# Altium schematic sheet 10 - POWER, RESET.SchDoc
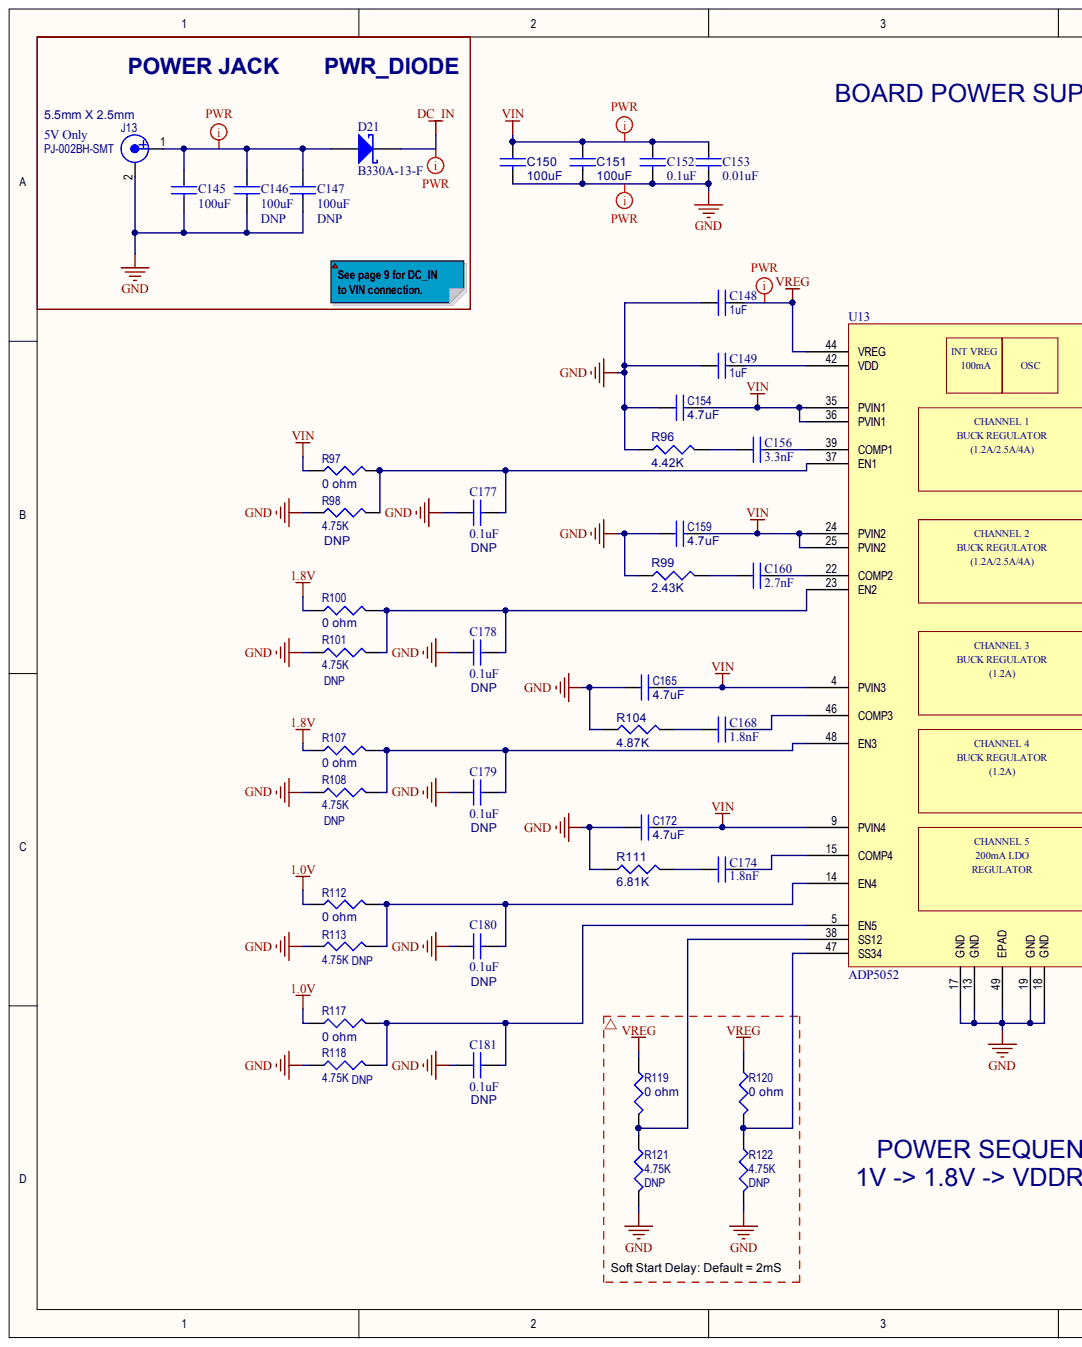
[diagram p0: 10 - POWER, RESET.SchDoc, left]
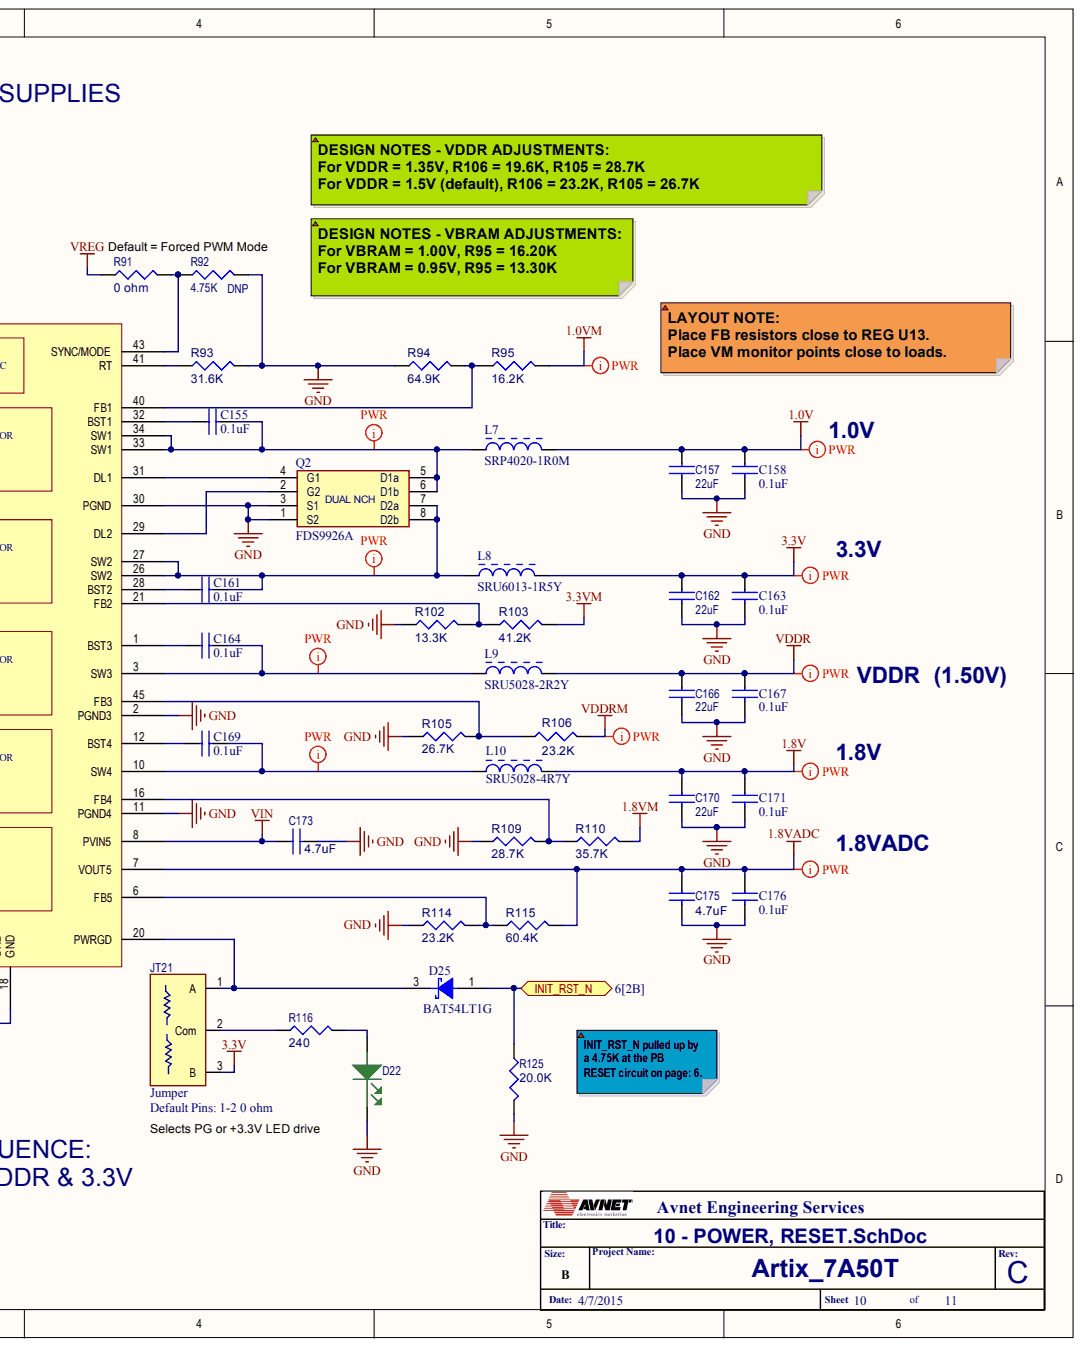
[diagram p1: 10 - POWER, RESET.SchDoc, right]

## Parts
C145  value 100uF  [C1210]  (hidden comment: PC074)
C146  value 100uF  [C1210]  (hidden comment: PC074)
C147  value 100uF  [C1210]  (hidden comment: PC074)
C148  value 1uF  [C0402]  (hidden comment: CAP_GEN_1uF_0402)
C149  value 1uF  [C0402]  (hidden comment: CAP_GEN_1uF_0402)
C150  100uF  [C1210]
C151  100uF  [C1210]
C152  value 0.1uF  [C0201]  (hidden comment: PC522)
C153  value 0.01uF  [C0201]  (hidden comment: PC003)
C154  value 4.7uF  [C0402]  (hidden comment: 4.8uF)
C155  value 0.1uF  [C0201]  (hidden comment: PC522)
C156  value 3.3nF  [C0201]  (hidden comment: ASYTXT)
C157  value 22uF  [C0805]  (hidden comment: GRM219R61A226MEA0D)
C158  value 0.1uF  [C0201]  (hidden comment: PC522)
C159  value 4.7uF  [C0402]  (hidden comment: 4.8uF)
C160  value 2.7nF  [C0201]  (hidden comment: ASYTXT)
C161  value 0.1uF  [C0201]  (hidden comment: PC522)
C162  value 22uF  [C0805]  (hidden comment: GRM219R61A226MEA0D)
C163  value 0.1uF  [C0201]  (hidden comment: PC522)
C164  value 0.1uF  [C0201]  (hidden comment: PC522)
C165  value 4.7uF  [C0402]  (hidden comment: 4.8uF)
C166  value 22uF  [C0805]  (hidden comment: GRM219R61A226MEA0D)
C167  value 0.1uF  [C0201]  (hidden comment: PC522)
C168  value 1.8nF  [C0201]  (hidden comment: ASYTXT)
C169  value 0.1uF  [C0201]  (hidden comment: PC522)
C170  value 22uF  [C0805]  (hidden comment: GRM219R61A226MEA0D)
C171  value 0.1uF  [C0201]  (hidden comment: PC522)
C172  value 4.7uF  [C0402]  (hidden comment: 4.8uF)
C173  value 4.7uF  [C0402]  (hidden comment: 4.8uF)
C174  value 1.8nF  [C0201]  (hidden comment: ASYTXT)
C175  value 4.7uF  [C0402]  (hidden comment: 4.8uF)
C176  value 0.1uF  [C0201]  (hidden comment: PC522)
C177  value 0.1uF  [C0201]  (hidden comment: PC522)
C178  value 0.1uF  [C0201]  (hidden comment: PC522)
C179  value 0.1uF  [C0201]  (hidden comment: PC522)
C180  value 0.1uF  [C0201]  (hidden comment: PC522)
C181  value 0.1uF  [C0201]  (hidden comment: PC522)
D21  Device: B330A-13-F  [SMA]  (hidden comment: DD031)
D22  DL018  [LED_LITE-LTST-C191TBKT-G]  (value not drawn)
D25  BAT54LT1G  [SOT23]
J13  Device: PJ-002BH-SMT  [CON_CUI-PJ-002B-SMT]  (hidden comment: EC068)
JT21  value 0 ohm  Default Pins: 1-2 0 ohm  Device: Jumper  [R0402-DUAL_JMP]
L7  SRP4020-1R0M  [AVPI-1B]
L8  SRU6013-1R5Y  [AVPI-2C]
L9  SRU5028-2R2Y  [AVPI-2C]
L10  SRU5028-4R7Y  [AVPI-2C]
Q2  MFGPN: FDS9926A  [so8]  (hidden comment: FDS9926A DUAL MOSFET)
R91  0 ohm  [R0402]
R92  value 4.75K  [R0402]  (hidden comment: 0402)
R93  value 31.6K  [R0402]  (hidden comment: 0402 RES, 1%, Generic)
R94  value 64.9K  [R0402]  (hidden comment: 0402 RES, 1%, Generic)
R95  value 16.2K  [R0402]  (hidden comment: 0402 RES, 1%, Generic)
R96  value 4.42K  [R0402]  (hidden comment: 0402 RES, 1%, Generic)
R97  0 ohm  [R0402]
R98  value 4.75K  [R0402]  (hidden comment: 0402)
R99  value 2.43K  [R0402]  (hidden comment: 0402 RES, 1%, Generic)
R100  0 ohm  [R0402]
R101  value 4.75K  [R0402]  (hidden comment: 0402)
R102  value 13.3K  [R0402]  (hidden comment: 0402 RES, 1%, Generic)
R103  value 41.2K  [R0402]  (hidden comment: 0402 RES, 1%, Generic)
R104  value 4.87K  [R0402]  (hidden comment: 0402 RES, 1%, Generic)
R105  value 26.7K  [R0402]  (hidden comment: 0402 RES, 1%, Generic)
R106  value 23.2K  [R0402]  (hidden comment: 0402 RES, 1%, Generic)
R107  0 ohm  [R0402]
R108  value 4.75K  [R0402]  (hidden comment: 0402)
R109  value 28.7K  [R0402]  (hidden comment: 0402 RES, 1%, Generic)
R110  value 35.7K  [R0402]  (hidden comment: 0402 RES, 1%, Generic)
R111  value 6.81K  [R0402]  (hidden comment: 0402 RES, 1%, Generic)
R112  0 ohm  [R0402]
R113  value 4.75K  [R0402]  (hidden comment: 0402)
R114  value 23.2K  [R0402]  (hidden comment: 0402 RES, 1%, Generic)
R115  value 60.4K  [R0402]  (hidden comment: 0402 RES, 1%, Generic)
R116  240  [R0402]
R117  0 ohm  [R0402]
R118  value 4.75K  [R0402]  (hidden comment: 0402)
R119  0 ohm  [R0402]
R120  0 ohm  [R0402]
R121  value 4.75K  [R0402]  (hidden comment: 0402)
R122  value 4.75K  [R0402]  (hidden comment: 0402)
R125  20.0K  [R0402]
U13  ADP5052  [LFCSP48]

## Ports
INIT_RST_N

## Nets
1.0V: C157.1 C158.1 L7.2 R112.1 R117.1
1.0VM: R95.2
1.8V: C170.1 C171.1 L10.2 R100.1 R107.1
1.8VADC: C175.1 C176.1 R115.2 U13.7(VOUT5)
1.8VM: R110.2
3.3V: C162.1 C163.1 JT21.3 L8.2
3.3VM: R103.2
DC_IN: D21.C
GND: C145.2 C146.2 C147.2 C148.1 C149.1 C150.2 C151.2 C152.2 C153.2 C154.1 C157.2 C158.2 C159.1 C162.2 C163.2 C165.1 C166.2 C167.2 C170.2 C171.2 C172.1 C173.2 C175.2 C176.2 C177.1 C178.1 C179.1 C180.1 C181.1 D22.C J13.2 Q2.1(S2) Q2.3(S1) R92.2 R93.2 R94.1 R96.1 R98.1 R99.1 R101.1 R102.1 R104.1 R105.1 R108.1 R109.1 R111.1 R113.1 R114.1 R118.1 R121.2 R122.2 R125.2 U13.2(PGND3) U13.11(PGND4) U13.13(GND) U13.17(GND) U13.18(GND) U13.19(GND) U13.30(PGND) U13.49(EPAD)
VDDR: C166.1 C167.1 L9.2
VDDRM: R106.2
VIN: C150.1 C151.1 C152.1 C153.1 C154.2 C159.2 C165.2 C172.2 C173.1 R97.1 U13.4(PVIN3) U13.8(PVIN5) U13.9(PVIN4) U13.24(PVIN2) U13.25(PVIN2) U13.35(PVIN1) U13.36(PVIN1)
VREG: C148.2 R91.1 R119.1 R120.1 U13.44(VREG)
INIT_RST_N: D25.1 R125.1 ; port INIT_RST_N
NetC145_1: C145.1 C146.1 C147.1 D21.A J13.1
NetC149_2: C149.2 U13.42(VDD)
NetC155_1: C155.1 U13.32(BST1)
NetC155_2: C155.2 L7.1 Q2.5(D1a) Q2.6(D1b) U13.33(SW1) U13.34(SW1)
NetC156_1: C156.1 R96.2
NetC156_2: C156.2 U13.39(COMP1)
NetC160_1: C160.1 R99.2
NetC160_2: C160.2 U13.22(COMP2)
NetC161_1: C161.1 U13.28(BST2)
NetC161_2: C161.2 L8.1 Q2.7(D2a) Q2.8(D2b) U13.26(SW2) U13.27(SW2)
NetC164_1: C164.1 U13.1(BST3)
NetC164_2: C164.2 L9.1 U13.3(SW3)
NetC168_1: C168.1 R104.2
NetC168_2: C168.2 U13.46(COMP3)
NetC169_1: C169.1 U13.12(BST4)
NetC169_2: C169.2 L10.1 U13.10(SW4)
NetC174_1: C174.1 R111.2
NetC174_2: C174.2 U13.15(COMP4)
NetC177_2: C177.2 R97.2 R98.2 U13.37(EN1)
NetC178_2: C178.2 R100.2 R101.2 U13.23(EN2)
NetC179_2: C179.2 R107.2 R108.2 U13.48(EN3)
NetC180_2: C180.2 R112.2 R113.2 U13.14(EN4)
NetC181_2: C181.2 R117.2 R118.2 U13.5(EN5)
NetD22_A: D22.A R116.2
NetD25_3: D25.3 JT21.1 U13.20(PWRGD)
NetJT21_2: JT21.2 R116.1
NetQ2_2: Q2.2(G2) U13.29(DL2)
NetQ2_4: Q2.4(G1) U13.31(DL1)
NetR91_2: R91.2 R92.1 U13.43(SYNC/MODE)
NetR93_1: R93.1 U13.41(RT)
NetR94_2: R94.2 R95.1 U13.40(FB1)
NetR102_2: R102.2 R103.1 U13.21(FB2)
NetR105_2: R105.2 R106.1 U13.45(FB3)
NetR109_2: R109.2 R110.1 U13.16(FB4)
NetR114_2: R114.2 R115.1 U13.6(FB5)
NetR119_2: R119.2 R121.1 U13.38(SS12)
NetR120_2: R120.2 R122.1 U13.47(SS34)

## Library data of the parts
C145-C147 = AVPC-0000074: CAP SM 100uF 10V 10% X5R C1210; PartCode: PC; AVLNo: AVPC-0000530; Database Table Name: Passive-Cap$; MFG Code: TYC; MFGPN: EMK325ABJ107MM-T; Volt: 16V; AsyTxt: PC074; Component Kind: Standard; ComponentLink1Description: Datasheet; ComponentLink2Description: MFR Website; Device: CAP; Dielectric: X5R; ESR: CAP; PkgType: SM; RoHS: Yes; Status: Preliminary; Tol: 10%; ComponentLink1URL: C:\AVDBLIB\DATASHEETS\AVX_CX5R.pdf; Library Name: Avnet_DBlib.DbLib
  C146 C147: DNP: DNP
C148 C149 = CAP_GEN_1uF_0402: MFGPN: 04026D105KAT2A; PKG: 0402; Component Kind: Standard; Library Name: Artix_7A50.SCHLIB
C150 C151 = AVPC-0000209: CAP SM 100uF 6.3V 20% X5R C1206; PartCode: PC; AsyTxt: PC209; Database Table Name: Passive-Cap$; MFG Code: MUR; MFGPN: GRM32EF50J107ZE20K; Power: 0; Availability: 180K-AV; AVLNo: AVPC-0000209; Component Kind: Standard; ComponentLink1Description: Datasheet; Cost: .41; Device: CAP; Dielectric: X5R; PkgType: SM; Received: 0; RoHS: Yes; Size: 9.11; Status: Preliminary; Tol: 20%; Value: 100uF; Volt: 6.3V; ComponentLink1URL: C:\AVDBLIB\DATASHEETS\GRM31CR60J107ME39.pdf; Library Name: Avnet_DBlib.DbLib
C152 C155 C158 C161 C163 C164 C167 C169 C171 C176-C181 = AVPC-0000522: CAP SM 0.1uF 10V 10% X5R C0201; PartCode: PC; Database Table Name: Passive-Cap$; MFG Code: AVX; MFGPN: 02016D104KAT2A; AsyTxt: PC522; AVLNo: AVPC-0000522; Component Kind: Standard; ComponentLink1Description: Datasheet; Device: CAP; Dielectric: X5R; PkgType: SM; RoHS: Yes; Status: Preliminary; Tol: 10%; Volt: 10V; ComponentLink1URL: C:\AVDBLIB\DATASHEETS\AVX_CCOG.pdf; Library Name: Avnet_DBlib.DbLib
  C177-C181: DNP: DNP
C153 = AVPC-0000003: CAP SM 0.01uF 10V 10% X5R C0201; PartCode: PC; Database Table Name: Passive-Cap$; MFG Code: AVX; MFGPN: 0201ZD103KAT2A; AsyTxt: PC003; AVLNo: AVPC-0000003; Component Kind: Standard; ComponentLink1Description: Datasheet; ComponentLink2Description: MFR Website; ComponentLink2URL: www.avx.com; Device: CAP; Dielectric: X5R; ESR: CAP; PkgType: SM; RoHS: Yes; Status: Preliminary; Tol: 10%; Volt: 10V; ComponentLink1URL: C:\AVDBLIB\DATASHEETS\AVX_CX5R.pdf; Library Name: Avnet_DBlib.DbLib
C154 C159 C165 C172 C173 C175 = AVPC-0000499: CAP SM 4.7uF 6.3V 20% X5R C0402 85C; PartCode: PC; AsyTxt: PC499; Database Table Name: Passive-Cap$; MFG Code: AVX; MFGPN: GRM155R60J475ME87D; Power: 0; Availability: 140K-DK; AVLNo: AVPC-0000499; Component Kind: Standard; ComponentLink1Description: Datasheet; Cost: .46; Device: CAP; Dielectric: X5R; PkgType: SM; Received: 0; RoHS: Yes; Size: 1.9; Status: Preliminary; Tol: 20%; Volt: 6.3V; ComponentLink1URL: C:\AVDBLIB\DATASHEETS\murata_caps_c02e.pdf; Library Name: Avnet_DBlib.DbLib
C156 = AVPC-0000003: CAP SM 3300pF 16V 10% X7R C0201; Database Table Name: Passive-Cap$; MFG: MUR; MFGPN: GRM033R71C332KA88D; PKG: 0201; TEMP: -55+125; Component Kind: Standard; Dielectric: X7R; RoHS: Yes; Tol: 10%; Volt: 16V; Library Name: Avnet_DBlib.DbLib
C157 C162 C166 C170 = (no symbol name): CAP SM 22uF 10V 20% X5R C0805; PartCode: PC; AsyTxt: PC112; AVLNo: AVPC-0000531; ESR: CAP-P; MFG Code: TAI; MFGPN: LMK212BJ226MG-T; Tol: 10%; Component Kind: Standard; ComponentLink1Description: Datasheet; Device: CAP; Dielectric: X5R; Library Name: Zynq_Z010_ZedStick.SchLib; PkgType: SM; RoHS: Yes; Status: Preliminary; Volt: 10V
C160 = AVPC-0000003: CAP SM 2700pF 16V 10% X7R C0201; Database Table Name: Passive-Cap$; Dielectric: X5R; MFG: MUR; MFGPN: GRM033R61A272KA01D; PKG: 0201; TEMP: -55+85; Component Kind: Standard; Tol: 10%; Volt: 16V; Library Name: Avnet_DBlib.DbLib
C168 C174 = AVPC-0000003: CAP SM 1800pF 16V 10% X7R C0201; Database Table Name: Passive-Cap$; MFG: MUR; MFGPN: GRM033R71C182KA88D; PKG: 0201; TEMP: -55+125; Component Kind: Standard; Dielectric: X7R; Tol: 10%; Volt: 16V; Library Name: Avnet_DBlib.DbLib
D21 = AVDD-0000031: Diode SM Barrier Rectifier Schottky 3A 30V    DIO-S SMA; PartCode: DD; Database Table Name: Discrete-Diode$; MFG Code: DII; AsyTxt: DD031; AVLNo: AVDD-0000031; Component Kind: Standard; Device Sub Type: Barrier Rectifier; Device Type: Diode; Imax: 3A; MFGPN: B330A-13-F; PkgType: SM; Status: Preliminary; Technology: Schottky; Vr: 30V; Library Name: Avnet_DBlib.DbLib
D22 = AVDL-0000018: LED, GREEN  525nm  3.2V 20mA 150mcd  LED-G LED_LITE-LTST-C191TBKT-G; PartCode: DL; Color: GRN; Database Table Name: Discrete-LED$; Device: LTST-C191TGKT; MFG Code: LTP; Text: LED5; AsyTxt: DL018; AVLNo: AVDL-0000018; Component Kind: Standard; ComponentLink1Description: Datasheet; Device Type: LED, GREEN; If: 20mA; Intensity at If: 150mcd; MFGPN: LTST-C191TGKT; Status: Preliminary; Vf: 3.2V; WaveLength: 525nm; ComponentLink1URL: C:\AVDBLIB\DATASHEETS\LTST-C191TGKT.pdf; Library Name: Avnet_DBlib.DbLib
D25 = BAT54LT1G: Schottky Diodes Vf=0.35V@10mA Vr=30V; MFG#1/Part#: OnSemi: BAT54LT1G; Component Kind: Standard; Library Name: new.SchLib; MFGPN: BAT54LT1G
J13 = (no symbol name): CONN SM Barrel Jack 2.1MMX5.5MM  Barrel_Socket-2 CON_CUI-PJ-002BH-SMT; Alt1MFR: Digikey; PartCode: EC; Alt1PN: CP-002APJTR-ND; MFG Code: CUI; MFGPN: PJ-002BH-SMT-TR; Note: 2.5A; Volt: 5V Only; AsyTxt: EC068; Component Kind: Standard; ComponentLink1Description: Datasheet; Device Type: CONN; Library Name: Artix_7A50.SCHLIB; PkgType: SM; RoHS: Yes; Status: Preliminary; Sub Type: Barrel Jack; Technology: 2.5MMX5.5MM
JT21 = AVPO-0000025: Jumper 3-pad 0402 RES-3padJPR R0402-DUAL_JMP - 0 Ohm at Pads 1-2; PartCode: PO; Database Table Name: Passive-Other$; Device Type: 3-pad 0R0 0402; MFG Code: DAL; MFGPN: CRCW04020R00FKED: Placed at pads 1-2; PkgType: SM; Component Kind: Standard; ComponentLink1Description: Datasheet; RoHS: Yes; Status: Preliminary; ComponentLink1URL: C:\AVDBLIB\DATASHEETS\Dale_CRCW.pd; Library Name: Avnet_DBlib.DbLib
L7 = AVPI-0000101,A-0: FIXED IND 1uH 5A 21.6 mOHM SMD; Material: IRON; Mount Type (SM/TH): SM; Numeric Value (uH): 1; Preferred MFG: BOURNS; Preferrence Code: A; Component Kind: Standard; Component Type: INDUCTOR; DC Resistance (DCR): 21.6 mOHM; Package: 2-SMD (4.8 x 4 mm); Preferred MFGPN: SRP4020-1R0M; Rating: 5A; RoHS: Y; Value: 1uH; Library Name: AES ALTIUM VAULT
L8 = AVPI-0000276,A-1: FIXED IND 1.5uH 3A 40 mOHM SMD; DC Resistance (DCR): 40 mOHM; Material: FERRITE; Mount Type (SM/TH): SM; Numeric Value (uH): 1.5; Preferred MFG: BOURNS; Preferrence Code: A; Rating: 3.0A; Component Kind: Standard; Component Type: INDUCTOR; Package: 4-SMD (5.2 x 5.2 mm); Preferred MFGPN: SRU6013-1R5Y; RoHS: Y; Value: 1.5uH; Library Name: AES ALTIUM VAULT
L9 = AVPI-0000209,A-0: FIXED IND 2.2uH 3.2A 21 mOHM SMD; DC Resistance (DCR): 21 mOHM; Material: FERRITE; Mount Type (SM/TH): SM; Numeric Value (uH): 2.2; Preferred MFG: BOURNS; Preferrence Code: A; Component Kind: Standard; Component Type: INDUCTOR; Package: 4-SMD (5.2 x 5.2 mm); Preferred MFGPN: SRU5028-2R2Y; Rating: 3.2A; RoHS: Y; Value: 2.2uH; Library Name: AES ALTIUM VAULT
L10 = AVPI-0000213,A-0: FIXED IND 4.7uH 2.2A 32 mOHM SMD; DC Resistance (DCR): 32 mOHM; Material: FERRITE; Mount Type (SM/TH): SM; Numeric Value (uH): 4.7; Preferred MFG: BOURNS; Preferrence Code: A; Component Kind: Standard; Component Type: INDUCTOR; Package: 4-SMD (5.2 x 5.2 mm); Preferred MFGPN: SRU5028-4R7Y; Rating: 2.2A; RoHS: Y; Value: 4.7uH; Library Name: AES ALTIUM VAULT
Q2 = FDS9926A DUAL MOSFET: DUAL N CH MOSFET SO8, 6.5A; MFG: FCH; Component Kind: Standard; Library Name: Artix_7A50.SchLib; PKG: SO8
R91 R97 R100 R107 R112 R117 R119 R120 = AVPR-0000027: RES SM 0 ohm 62mW J R0402; PartCode: PR; AsyTxt: PR027; Database Table Name: Passive-Res$; MFG Code: DAL; MFGPN: CRCW04020000Z0ED; AVLNo: AVPR-0000027; Component Kind: Standard; ComponentLink1Description: Datasheet; Device: RES; PkgType: SM; RoHS: Yes; Status: Preliminary; Value: 0 ohm; Watt: 62mW; ComponentLink1URL: C:\AVDBLIB\DATASHEETS\Dale_CRCW.pd; Library Name: Avnet_DBlib.DbLib
R92 R98 R101 R108 R113 R118 R121 R122 = RESC 4K75 0402: Res Thick Film 0402 4.75K Ohm 1% 1/16W ±100ppm/°C Molded SMD SMD Paper T/R; MFG Code: DAL; MFGPN: CRCW04024K75FKED; Component Kind: Standard; DNP: DNP; Note: Res Thick Film 0402 4.75K Ohm 1% 1/16W ±100ppm/°C Molded SMD SMD Paper T/R; Signal Integrity: RES; Simulation: RES; Library Name: ZYNQ_Z7045_MITX_REVA.SCHLIB
R93 = RES_GEN_0402: 31.6K resistor, 1%, 0402; MFGPN: CRCW040231K6FKED; PKG: 0402; Component Kind: Standard; Library Name: Artix_7A50.SCHLIB
R94 = RES_GEN_0402: 64.9K resistor, 1%, 0402; MFGPN: CRCW040264K9FKED; PKG: 0402; Component Kind: Standard; Library Name: Artix_7A50.SCHLIB
R95 = RES_GEN_0402: 16.2K resistor, 1%, 0402; MFGPN: CRCW040216K2FKED; PKG: 0402; Component Kind: Standard; Library Name: Artix_7A50.SCHLIB
R96 = RES_GEN_0402: 4.42K resistor, 1%, 0402; MFGPN: CRCW04024K42FKED; PKG: 0402; Component Kind: Standard; Library Name: Artix_7A50.SCHLIB
R99 = RES_GEN_0402: 2.43K resistor, 1%, 0402; MFGPN: CRCW04022K43FKED; PKG: 0402; Component Kind: Standard; Library Name: Artix_7A50.SCHLIB
R102 = RES_GEN_0402: 13.3K resistor, 1%, 0402; MFGPN: CRCW040213K3FKED; PKG: 0402; Component Kind: Standard; Library Name: Artix_7A50.SCHLIB
R103 = RES_GEN_0402: 41.2K resistor, 1%, 0402; MFGPN: CRCW040241K2FKED; PKG: 0402; Component Kind: Standard; Library Name: Artix_7A50.SCHLIB
R104 = RES_GEN_0402: 4.87K resistor, 1%, 0402; MFGPN: CRCW04024K87FKED; PKG: 0402; Component Kind: Standard; Library Name: Artix_7A50.SCHLIB
R105 = RES_GEN_0402: 26.7K resistor, 1%, 0402; MFGPN: CRCW040226K7FKED; PKG: 0402; Component Kind: Standard; Library Name: Artix_7A50.SCHLIB
R106 R114 = RES_GEN_0402: 23.2K resistor, 1%, 0402; MFGPN: CRCW040223K2FKED; PKG: 0402; Component Kind: Standard; Library Name: Artix_7A50.SCHLIB
R109 = RES_GEN_0402: 28.7K resistor, 1%, 0402; MFGPN: CRCW040228K7FKED; PKG: 0402; Component Kind: Standard; Library Name: Artix_7A50.SCHLIB
R110 = RES_GEN_0402: 35.7K resistor, 1%, 0402; MFGPN: CRCW040235K7FKED; PKG: 0402; Component Kind: Standard; Library Name: Artix_7A50.SCHLIB
R111 = RES_GEN_0402: 6.81K resistor, 1%, 0402; MFGPN: CRCW04026K81FKED; PKG: 0402; Component Kind: Standard; Library Name: Artix_7A50.SCHLIB
R115 = RES_GEN_0402: 60.4K resistor, 1%, 0402; MFGPN: CRCW040260K4FKED; PKG: 0402; Component Kind: Standard; Library Name: Artix_7A50.SCHLIB
R116 = AVPR-0000511: RES SM 240 62mW 0.01 R0402; Alt1MFR: NIC; PartCode: PR; Alt1PN: NRC04F2400TRF; AsyTxt: PR511; Database Table Name: Passive-Res$; MFG Code: DAL; MFGPN: CRCW0402240RFKED; Power: 0; Tol: 0.01; Availability: 1M-AV; AVLNo: AVPR-0000511; Component Kind: Standard; ComponentLink1Description: Datasheet; Cost: .01; Device: RES; PkgType: SM; Received: 0; RoHS: Yes; Size: 1.9; Status: Preliminary; Value: 240; Watt: 62mW; ComponentLink1URL: C:\AVDBLIB\DATASHEETS\Dale_CRCW.pd; Library Name: Avnet_DBlib.DbLib
R125 = AVPR-0000061: RES SM 20.0K 62mW 0.01 R0402; PartCode: PR; AsyTxt: PR061; Database Table Name: Passive-Res$; MFG Code: DAL; MFGPN: CRCW040220K0FKED; Tol: 0.01; AVLNo: AVPR-0000061; Component Kind: Standard; ComponentLink1Description: Datasheet; Device: RES; PkgType: SM; RoHS: Yes; Status: Preliminary; Value: 20.0K; Watt: 62mW; ComponentLink1URL: C:\AVDBLIB\DATASHEETS\Dale_CRCW.pd; Library Name: Avnet_DBlib.DbLib
U13 = ADP5052: 5-CH Power w/ Quad Buck Reg and 200mA LDO; MFG: AD; Component Kind: Standard; Library Name: Artix_7A50.SchLib; MFGPN: ADP5052
